# Altium schematic sheet Sheet1.SchDoc(Sheet1)
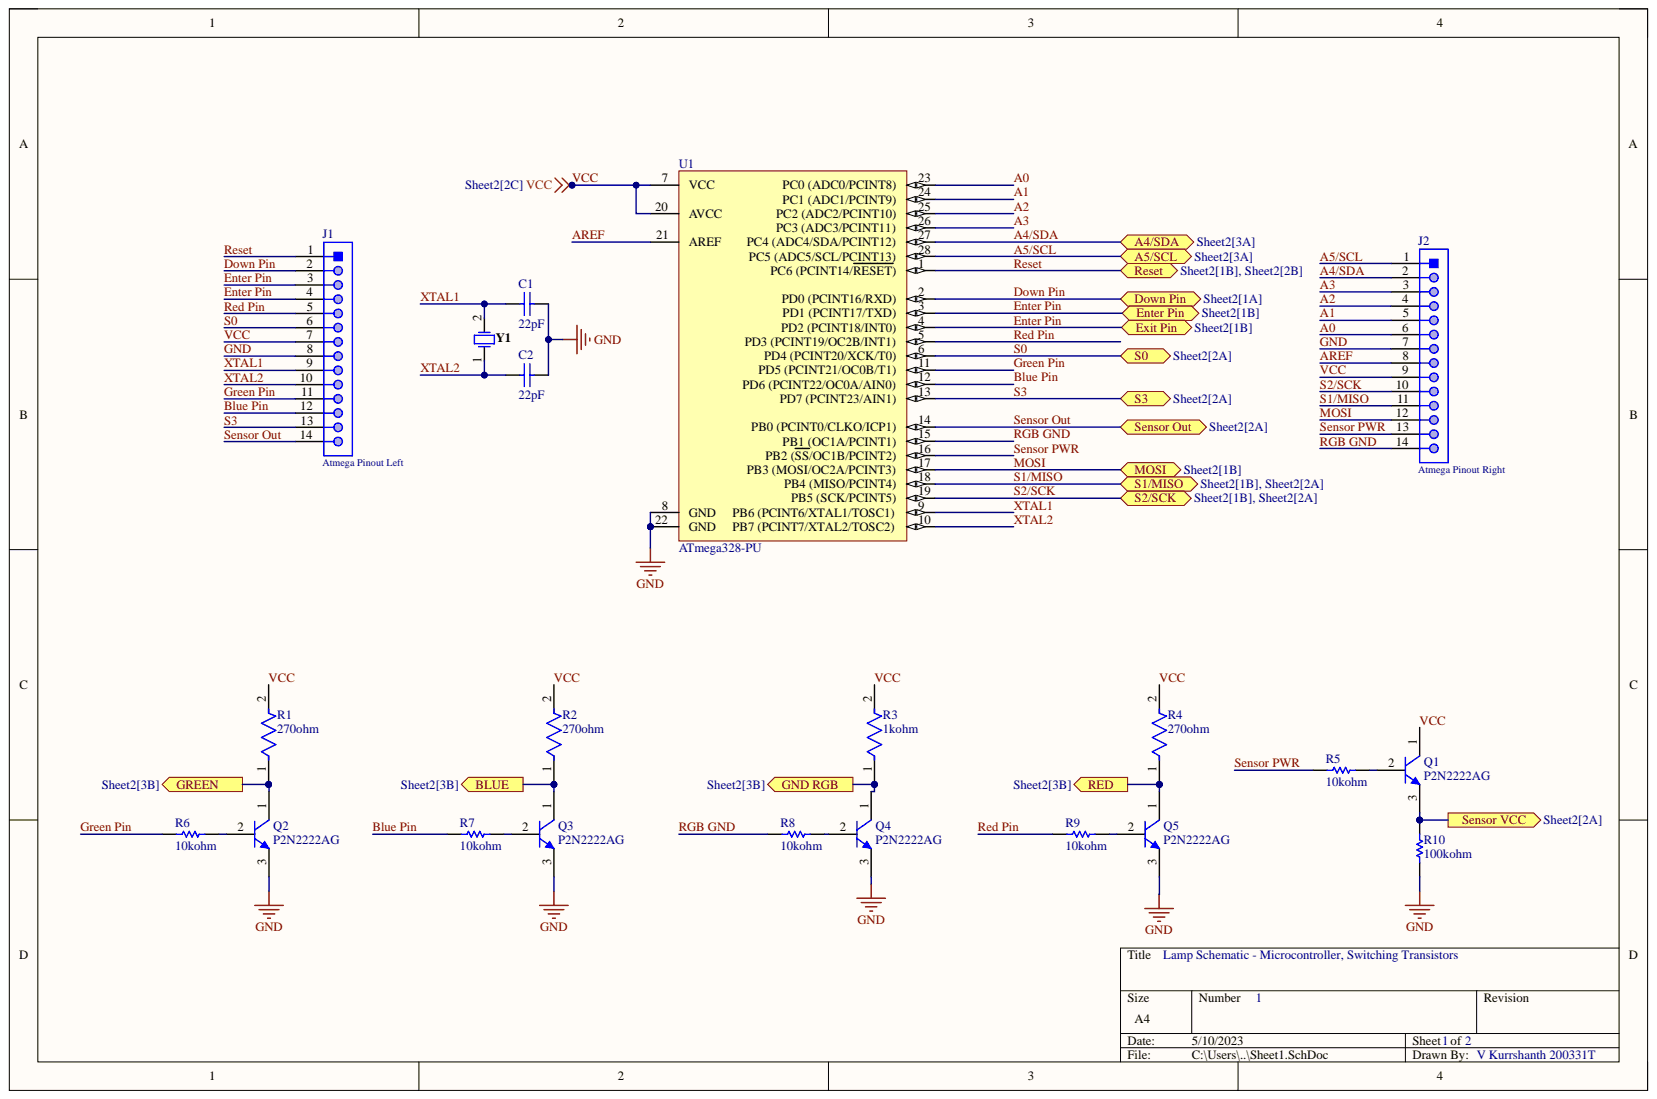
[diagram p0: Sheet1.SchDoc]

## Parts
C1  22pF  [KEMT-C315-THT-2]
C2  22pF  [KEMT-C315-THT-2]
J1  Atmega Pinout Left  [61301411121]
J2  Atmega Pinout Right  [61301411121]
Q1  P2N2222AG  [ONSC-TO-92-3-29-11]
Q2  P2N2222AG  [ONSC-TO-92-3-29-11]
Q3  P2N2222AG  [ONSC-TO-92-3-29-11]
Q4  P2N2222AG  [ONSC-TO-92-3-29-11]
Q5  P2N2222AG  [ONSC-TO-92-3-29-11]
R1  270ohm  [FP-CFR50J2K2-MFG]
R2  270ohm  [FP-CFR50J2K2-MFG]
R3  1kohm  [FP-CFR50J2K2-MFG]
R4  270ohm  [FP-CFR50J2K2-MFG]
R5  10kohm  [RESA60-630X240]
R6  10kohm  [RESA60-630X240]
R7  10kohm  [RESA60-630X240]
R8  10kohm  [RESA60-630X240]
R9  10kohm  [RESA60-630X240]
R10  100kohm  [RESA60-630X240]
U1  ATmega328-PU  [28P3]
Y1  FOXSLF/160-20  [FOX-FOXSLF160-20]  (value not drawn)

## Ports
A4/SDA, A5/SCL, BLUE, Down Pin, Enter Pin, Exit Pin, GND RGB, GREEN, MOSI, RED, Reset, S0, S1/MISO, S2/SCK, S3, Sensor Out, Sensor VCC

## Nets
A0: J2.6 U1.23(PC0_(ADC0/PCINT8))
A1: J2.5 U1.24(PC1_(ADC1/PCINT9))
A2: J2.4 U1.25
A3: J2.3 U1.26(PC3_(ADC3/PCINT11))
A4/SDA: J2.2 U1.27 ; port A4/SDA
A5/SCL: J2.1 U1.28(PC5_(ADC5/SCL/PCINT13)) ; port A5/SCL
AREF: J2.8 U1.21(AREF)
BLUE: Q3.1 R2.1 ; port BLUE
Blue Pin: J1.12 R7.1 U1.12(PD6_(PCINT22/OC0A/AIN0))
Down Pin: J1.2 U1.2(PD0_(PCINT16/RXD)) ; port Down Pin
Enter Pin: J1.3 J1.4 U1.3(PD1_(PCINT17/TXD)) U1.4(PD2_(PCINT18/INT0)) ; port Enter Pin, port Exit Pin
GND: C1.2 C2.2 J1.8 J2.7 Q2.3 Q3.3 Q4.3 Q5.3 R10.1 U1.8(GND) U1.22(GND)
GND RGB: Q4.1 R3.1 ; port GND RGB
GREEN: Q2.1 R1.1 ; port GREEN
Green Pin: J1.11 R6.1 U1.11(PD5_(PCINT21/OC0B/T1))
MOSI: J2.12 U1.17(PB3_(MOSI/OC2A/PCINT3)) ; port MOSI
RED: Q5.1 R4.1 ; port RED
Red Pin: J1.5 R9.1 U1.5(PD3_(PCINT19/OC2B/INT1))
Reset: J1.1 U1.1(PC6_(PCINT14/RESET)) ; port Reset
RGB GND: J2.14 R8.1 U1.15(PB1_(OC1A/PCINT1))
S0: J1.6 U1.6(PD4_(PCINT20/XCK/T0)) ; port S0
S1/MISO: J2.11 U1.18(PB4_(MISO/PCINT4)) ; port S1/MISO
S2/SCK: J2.10 U1.19(PB5_(SCK/PCINT5)) ; port S2/SCK
S3: J1.13 U1.13(PD7_(PCINT23/AIN1)) ; port S3
Sensor Out: J1.14 U1.14(PB0_(PCINT0/CLKO/ICP1)) ; port Sensor Out
Sensor PWR: J2.13 R5.1 U1.16(PB2_(SS/OC1B/PCINT2))
Sensor VCC: Q1.3 R10.2 ; port Sensor VCC
VCC: J1.7 J2.9 Q1.1 R1.2 R2.2 R3.2 R4.2 U1.7(VCC) U1.20(AVCC)
XTAL1: C1.1 J1.9 U1.9 Y1.2
XTAL2: C2.1 J1.10 U1.10 Y1.1
NetQ1_2: Q1.2 R5.2
NetQ2_2: Q2.2 R6.2
NetQ3_2: Q3.2 R7.2
NetQ4_2: Q4.2 R8.2
NetQ5_2: Q5.2 R9.2

## Library data of the parts
C1 C2 = CMP-1664-00008-1: Capacitor, Ceramic, 22 pF, +/-10 %, 100 V, -55 to 125 degC, 2-Pin THD, RoHS, Bulk; Case\Package: TO-220; Max Input Voltage: 40 V; Max Ouput Current: 1.5 A; Min Input Voltage: 4.2 V; Output Voltage: 1.2 V; Outputs: 1; Component Kind: Standard; ComponentLink1Description: Manufacturer URL; ComponentLink1URL: http://www.kemet.com/; ComponentLink2Description: Datasheet; ComponentLink2URL: http://capacitoredge.kemet.com/capedge2/DataSheet/Datasheet-C315C220K1G5TA.pdf?pn=C315C220K1G5TA{BULK}; DatasheetVersion: Aug-16; Height: 9.28 mm; Length: 10.28 mm; Library Name: Altium Content Vault; Max Operating Temperature: 125 degC; Min Operating Temperature: 0 degC; Mount: Through Hole; Output Current: 1.5 A; Package Quantity: 50; PackageDescription: 2-Pin Through Hole Device, Body 3.81 x 2.54 mm; PackageReference: C315-THT-2; Packaging: Rail/Tube; Pins: 3; Radiation Hardening: No; REACH SVHC: No SVHC; RoHSCompliant: true; Weight: 0.08113 oz; Width: 4.82 mm
J1 J2 = CMP-1502-01091-1: THT Vertical Pin Header WR-PHD, Pitch 2.54 mm, Single Row, 14 pins; Manufacturer: Wurth Elektronik; Contact Resistance: 20mOhm; Gender: Male; Pin Number: 14; Pitch: 2.54mm; Rated Current: 3A; Withstanding Voltage: 500VAC; Working Voltage: 250VAC; Component Kind: Standard; Datasheet URL: https://katalog.we-online.de/em/datasheet/6130xx11121.pdf; Library Name: Altium Content Vault; Manufacturer URL: http://www.we-online.de; Mounting Technology: THT; Operating Temperature: -40to105degC; Orientation: Vertical; Package Reference: 61301411121; Packaging: Bag; Part Number: 61301411121; Row Number: Single; Publisher: WurthElektronik
Q1-Q5 = CMP-1048-01402-1: Amplifier Transistor, NPN Silicon, 3-Pin TO-92, Pb-Free, Bulk Box; Manufacturer: On Semiconductor; fT Min (MHz): 300; IC Continuous (A): 0.6; Component Kind: Standard; ComponentLink1Description: Manufacturer URL; ComponentLink1URL: http://www.onsemi.com/; ComponentLink2Description: Datasheet; ComponentLink2URL: http://www.onsemi.com/pub_link/Collateral/P2N2222A-D.PDF; ComponentLink3Description: Package Specification; ComponentLink3URL: http://www.onsemi.cn/pub_link/Collateral/2N6426-D.PDF; DatasheetVersion: Rev. 7; Library Name: Altium Content Vault; Mounting Technology: Through Hole; PackageDescription: 3-Pin Transistor Outline, Body 4.825 x 3.685 mm, Pitch 1.27 mm; PackageReference: TO-92-3-29-11_AM_SL; PackageVersion: Rev. AM; Packing: Bulk Box; PartNumber: P2N2222AG; Polarity: NPN; RoHS: TRUE
R1 R2 R4 = CMP-03212-000011-1: RES 270 OHM 5% 1/2W AXIAL; Max Power Dissipation: 500mW; Number of Resistors: 1; Resistance: 2.2kR; Temperature Coefficient: 0ppm/°C; Voltage Rating: 350V; Case/Package: Axial; Component Kind: Standard; Diameter: 3mm; ELV: Compliant; Length: 9mm; Library Name: Altium Content Vault; Max Operating Temperature: 155°C; Min Operating Temperature: -55°C; Mount: Axial; Number of Terminations: 2; Package Quantity: 3000; Packaging: Cut Tape; Power Rating: 500mW; Radiation Hardening: No; REACH SVHC: No SVHC; Resistance Tolerance: 5%; Resistor Type: Thin Film; RoHS Compliant: Yes; Series: CFR50, Neohm; Technology: CARBON FILM; Termination: Axial; Tolerance: 5%; Voltage Rating (DC): 350V
R3 = CMP-03212-000011-1: RES 1k OHM 5% 1/2W AXIAL; Max Power Dissipation: 500mW; Number of Resistors: 1; Resistance: 2.2kR; Temperature Coefficient: 0ppm/°C; Voltage Rating: 350V; Case/Package: Axial; Component Kind: Standard; Diameter: 3mm; ELV: Compliant; Length: 9mm; Library Name: Altium Content Vault; Max Operating Temperature: 155°C; Min Operating Temperature: -55°C; Mount: Axial; Number of Terminations: 2; Package Quantity: 3000; Packaging: Cut Tape; Power Rating: 500mW; Radiation Hardening: No; REACH SVHC: No SVHC; Resistance Tolerance: 5%; Resistor Type: Thin Film; RoHS Compliant: Yes; Series: CFR50, Neohm; Technology: CARBON FILM; Termination: Axial; Tolerance: 5%; Voltage Rating (DC): 350V
R5-R9 = CMP-1659-00074-2: Axial Resistor, 10 KOhm, +/- 1%, 0.25 W, -55 to 155 degC, 2-Pin THD, RoHS, Bulk; Manufacturer: Yageo; Max Power Dissipation: 250mW; Temperature Coefficient: 100ppm\/degreesC; Voltage Rating: 250V; Voltage Rating (DC): 200V; Case\/Package: Axial; Component Kind: Standard; Composition: MetalFilm; Datasheet URL: http://www.yageo.com/documents/recent/Yageo%20LR_MFR_2013.pdf; Datasheet Version: 04/2013; Lead Free: LeadFree; Length: 0.248inch; Library Name: Altium Content Vault; Manufacturer URL: http://www.yageo.com/; Max Operating Temperature: 155degC; Military Standard: Not; Min Operating Temperature: -55degC; Mount: ThroughHole; Mounting Technology: ThroughHole; Number of Terminations: 2; Package Description: 2-Pin Axial Lead, Dia 2.4 mm, Body Length 6.3 mm; Package Quantity: 200; Package Reference: MFR-25; Packaging: Bulk; Power Rating: 250mW; Resistance: 10KOhm; RoHSCompliant: True; Termination: Axial; Tolerance: 1%
R10 = CMP-1659-00074-2: Axial Resistor, 100 KOhm, +/- 1%, 0.25 W, -55 to 155 degC, 2-Pin THD, RoHS, Bulk; Manufacturer: Yageo; Max Power Dissipation: 250mW; Resistance: 10KOhm; Temperature Coefficient: 100ppm\/degreesC; Voltage Rating: 250V; Voltage Rating (DC): 200V; Case\/Package: Axial; Component Kind: Standard; Composition: MetalFilm; Datasheet URL: http://www.yageo.com/documents/recent/Yageo%20LR_MFR_2013.pdf; Datasheet Version: 04/2013; Lead Free: LeadFree; Length: 0.248inch; Library Name: Altium Content Vault; Manufacturer URL: http://www.yageo.com/; Max Operating Temperature: 155degC; Military Standard: Not; Min Operating Temperature: -55degC; Mount: ThroughHole; Mounting Technology: ThroughHole; Number of Terminations: 2; Package Description: 2-Pin Axial Lead, Dia 2.4 mm, Body Length 6.3 mm; Package Quantity: 200; Package Reference: MFR-25; Packaging: Bulk; Power Rating: 250mW; RoHSCompliant: True; Termination: Axial; Tolerance: 1%
U1 = CMP-0095-00015-2: 8-bit AVR Microcontroller, 32KB Flash, 1KB EEPROM, 2KB SRAM, 28-pin PDIP, Industrial Grade (-40°C to 85°C); Component Kind: Standard; ComponentLink1Description: Manufacturer URL; ComponentLink1URL: http://www.atmel.com/; ComponentLink2Description: ATmega48A/48PA/88A/88PA/168A/168PA/328/328P Datasheet; ComponentLink2URL: http://www.atmel.com/dyn/resources/prod_documents/8271.pdf; ComponentLink3Description: ATmega48A/48PA/88A/88PA/168A/168PA/328/328P Summary; ComponentLink3URL: http://www.atmel.com/dyn/resources/prod_documents/8271S.pdf; DatasheetVersion: revD, May-2011; Library Name: Altium Content Vault; PackageDescription: 28-lead (0.300"/7.62mm Wide) Plastic Dual Inline Package (PDIP); PackageReference: 28P3; PackageVersion: revB, Sep-2001; RevisionNote: DatasheetVersion updated. URLs added.
Y1 = CMP-1749-00003-1: Resistance Weld Thru-Hole Crystal, 16 MHz, -20 to 70 degC, 2-Pin THD, RoHS, Bulk; Case\Package: Axial; Power Rating: 250 mW; Resistance: 221 kohm; Tolerance: 1%; Voltage Rating: 250 V; Component Kind: Standard; ComponentLink1Description: Manufacturer URL; ComponentLink1URL: http://www.foxonline.com/; ComponentLink2Description: Datasheet; ComponentLink2URL: http://www.foxonline.com/pdfs/hc49slf.pdf; DatasheetVersion: Mar-15; Length: 0.248 inch; Library Name: Altium Content Vault; Max Operating Temperature: 155 degC; Min Operating Temperature: -55 degC; Mount: Through Hole; Package Quantity: 200; PackageDescription: 2-Pin Through Hole Device, Body 11.35 x 4.65 mm; PackageReference: FOXSLF160-20; Packaging: Bulk; RoHSCompliant: true
